# Altium schematic sheet Base IC.SchDoc
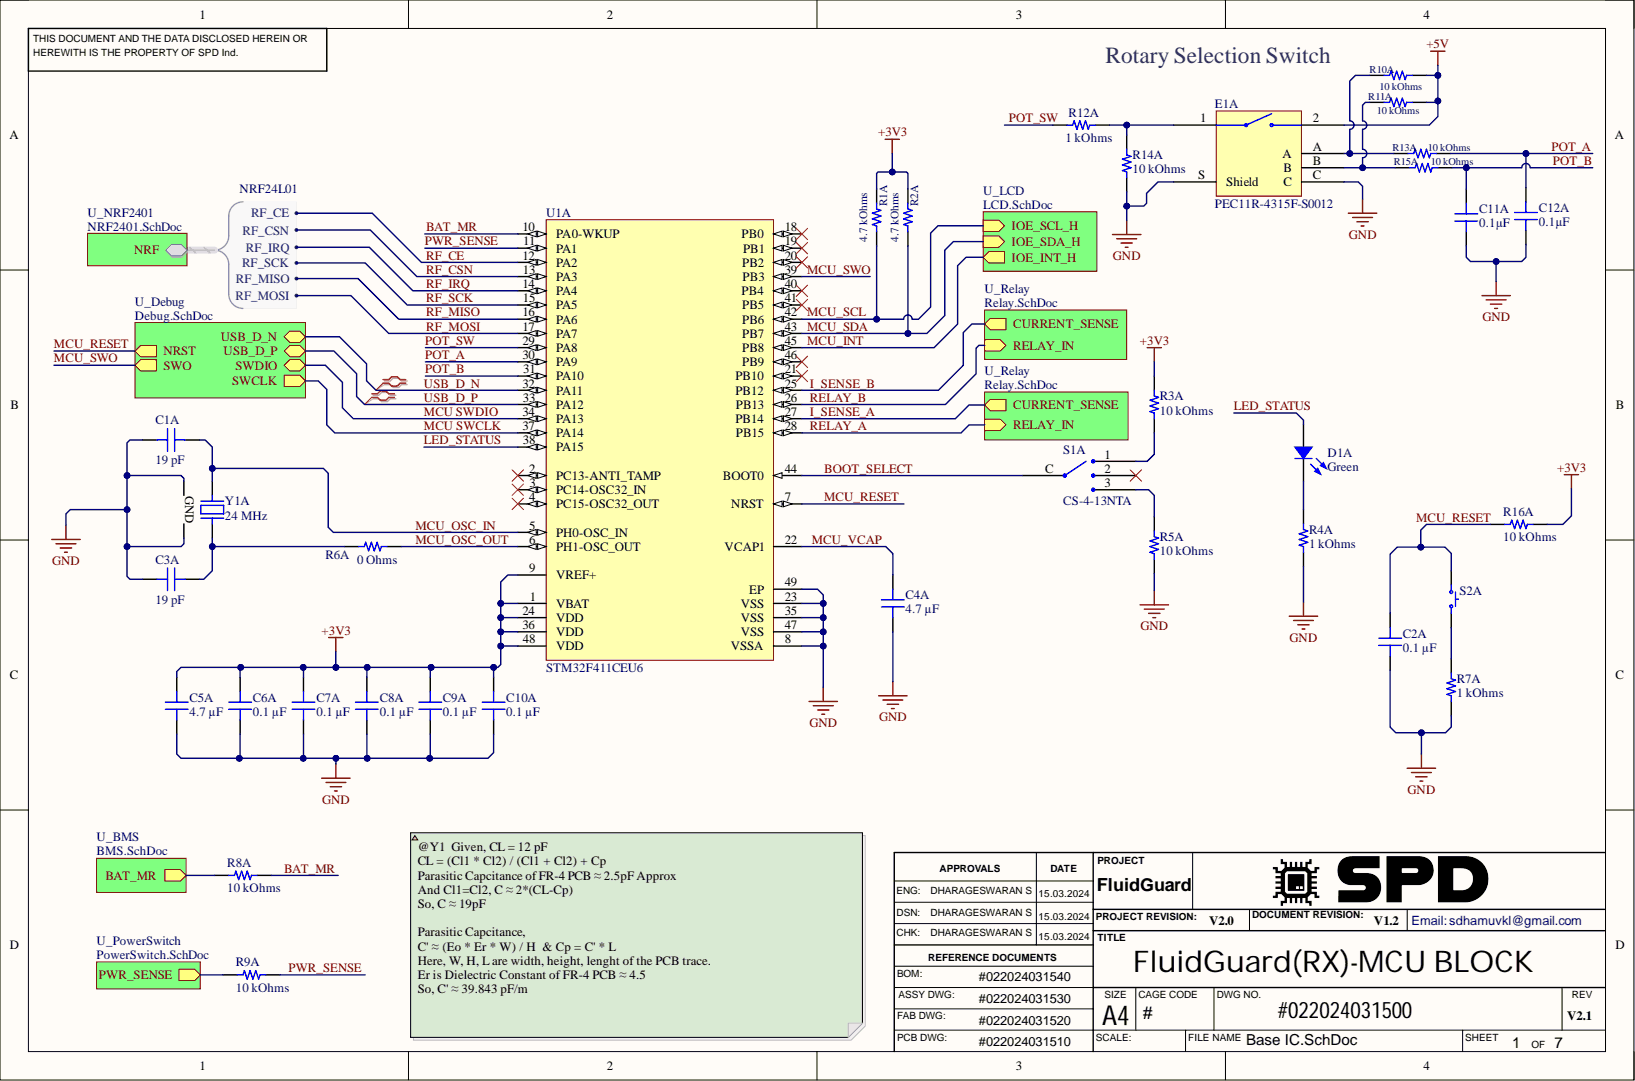
[diagram p0: Base IC.SchDoc]

## Parts
C1A  19 pF  [CAP 0402_1005]
C2A  0.1 µF  [CAP 0402_1005]
C3A  19 pF  [CAP 0402_1005]
C4A  4.7 µF  [CAP 0402_1005]
C5A  4.7 µF  [CAP 0402_1005]
C6A  0.1 µF  [CAP 0402_1005]
C7A  0.1 µF  [CAP 0402_1005]
C8A  0.1 µF  [CAP 0402_1005]
C9A  0.1 µF  [CAP 0402_1005]
C10A  0.1 µF  [CAP 0402_1005]
C11A  0.1µF  [CAP 0402_1005]
C12A  0.1µF  [CAP 0402_1005]
D1A  Green  [LED 0402_1005 GREEN]
E1A  PEC11R-4315F-S0012  [BOURNS PEC11R-4315F-S0012]
R1A  4.7 kOhms  [RES 0402_1005]
R2A  4.7 kOhms  [RES 0402_1005]
R3A  10 kOhms  [RES 0402_1005]
R4A  1 kOhms  [RES 0402_1005]
R5A  10 kOhms  [RES 0402_1005]
R6A  0 Ohms  [RES 0402_1005]
R7A  1 kOhms  [RES 0402_1005]
R8A  10 kOhms  [RES 0402_1005]
R9A  10 kOhms  [RES 0402_1005]
R10A  10 kOhms  [RES 0402_1005]
R11A  10 kOhms  [RES 0402_1005]
R12A  1 kOhms  [RES 0402_1005]
R13A  10 kOhms  [RES 0402_1005]
R14A  10 kOhms  [RES 0402_1005]
R15A  10 kOhms  [RES 0402_1005]
R16A  10 kOhms  [RES 0402_1005]
S1A  CS-4-13NTA  [NIDEC COPAL CS-4-13NTA]
S2A  EVP-AWED4A  [PANASONIC EVPAW]  (value not drawn)
U1A  STM32F411CEU6  [STM-UFQFPN48_L]
Y1A  24 MHz  [EPSON TSX-3225]

## Nets
+3V3: C5A.2 C6A.2 C7A.2 C8A.2 C9A.2 C10A.2 R1A.2 R2A.2 R3A.2 R16A.1 U1A.1(VBAT) U1A.9(VREF+) U1A.24(VDD) U1A.36(VDD) U1A.48(VDD)
+5V: E1A.2 R10A.2 R11A.2
GND: C1A.1 C2A.1 C3A.1 C4A.1 C5A.1 C6A.1 C7A.1 C8A.1 C9A.1 C10A.1 C11A.1 C12A.1 E1A.C E1A.S(Shield) R4A.1 R5A.1 R7A.1 R14A.1 U1A.8(VSSA) U1A.23(VSS) U1A.35(VSS) U1A.47(VSS) U1A.49(EP) Y1A.2 Y1A.4
BAT_MR: R8A.1 U1A.10(PA0-WKUP)
BOOT_SELECT: S1A.C U1A.44(BOOT0)
I_SENSE_A: U1A.27(PB14)
I_SENSE_B: U1A.25(PB12)
LED_STATUS: D1A.1 U1A.38(PA15)
MCU SWCLK: U1A.37(PA14)
MCU SWDIO: U1A.34(PA13)
MCU_INT: U1A.45(PB8)
MCU_OSC_IN: C1A.2 U1A.5(PH0-OSC_IN) Y1A.1
MCU_OSC_OUT: R6A.2 U1A.6(PH1-OSC_OUT)
MCU_RESET: C2A.2 R16A.2 S2A.1 U1A.7(NRST)
MCU_SCL: R1A.1 U1A.42(PB6)
MCU_SDA: R2A.1 U1A.43(PB7)
MCU_SWO: U1A.39(PB3)
MCU_VCAP: C4A.2 U1A.22(VCAP1)
POT_A: C12A.2 R13A.1 U1A.30(PA9)
POT_B: C11A.2 R15A.1 U1A.31(PA10)
POT_SW: R12A.2 U1A.29(PA8)
PWR_SENSE: R9A.1 U1A.11(PA1)
RELAY_A: U1A.28(PB15)
RELAY_B: U1A.26(PB13)
RF_CE: U1A.12(PA2)
RF_CSN: U1A.13(PA3)
RF_IRQ: U1A.14(PA4)
RF_MISO: U1A.16(PA6)
RF_MOSI: U1A.17(PA7)
RF_SCK: U1A.15(PA5)
USB_D_N: U1A.32(PA11)
USB_D_P: U1A.33(PA12)
NetC3A_2: C3A.2 R6A.1 Y1A.3
NetD1A_2: D1A.2 R4A.2
NetE1A_1: E1A.1 R12A.1 R14A.2
NetE1A_A: E1A.A R10A.1 R13A.2
NetE1A_B: E1A.B R11A.1 R15A.2
NetR3A_1: R3A.1 S1A.1
NetR5A_2: R5A.2 S1A.3
NetR7A_2: R7A.2 S2A.2
NetR8A_2: R8A.2
NetR9A_2: R9A.2
NetS1A_2: S1A.2
NetU1A_2: U1A.2(PC13-ANTI_TAMP)
NetU1A_3: U1A.3(PC14-OSC32_IN)
NetU1A_4: U1A.4(PC15-OSC32_OUT)
NetU1A_18: U1A.18(PB0)
NetU1A_19: U1A.19(PB1)
NetU1A_20: U1A.20(PB2)
NetU1A_21: U1A.21(PB10)
NetU1A_40: U1A.40(PB4)
NetU1A_41: U1A.41(PB5)
NetU1A_46: U1A.46(PB9)

## Library data of the parts
C1A C3A = GJM1555C1H190GB01D: CAP CER 19PF 50VDC 0402 C0G 2%; Manufacturer: Murata Electronics; Database Table Name: altium.Capacitors-Ceramic-0402; id: 5279; Temperature Coefficient: C0G, NP0; Voltage - Rated: 50V; Applications: RF, Microwave, High Frequency; Capacitance: 19 pF; Component Kind: Standard; ComponentLink1Description: Datasheet; ComponentLink1URL: https://search.murata.co.jp/Ceramy/image/img/A01X/G101/ENG/GJM1555C1H190GB01-01A.pdf; ComponentLink2Description: DigiKey Link; ComponentLink2URL: https://www.digikey.com/en/products/detail/murata-electronics/GJM1555C1H190GB01D/16033843; Device Package: 0402 (1005 Metric); Features: High Q, Low Loss; LastUpdated: 2023-01-03T20:03:22.137; Lifecycle Status: Active; Minimum Order: 1; Mounting Type: Surface Mount, MLCC; Operating Temperature: -55°C ~ 125°C; Package / Case: 0402 (1005 Metric); Packaging: TapeAndReel; Part Number: GJM1555C1H190GB01D; Part Status: Active; PartId: 41775; Price: 0.02; Series: GJM; Size / Dimension: 0.039" L x 0.020" W (1.00mm x 0.50mm); Supplier 1: DigiKey; Supplier Part Number 1: 490-GJM1555C1H190GB01DCT-ND; Thickness (Max): 0.022" (0.55mm); Tolerance: ±2%; Value: 19 pF; Library Name: Postgres Altium Library - altium_library.DbLib
C2A C6A C7A C8A C9A C10A = CL05B104KP5VPNC: CAP CER 100NF 10V X7R 0402; Manufacturer: Samsung Electro-Mechanics; Database Table Name: altium.Capacitors-Ceramic-0402; id: 7736; Tolerance: ±10%; Applications: Automotive; Capacitance: 0.1 µF; Component Kind: Standard; ComponentLink1Description: Datasheet; ComponentLink1URL: https://mm.digikey.com/Volume0/opasdata/d220001/medias/docus/5458/CL05B104KP5VPNC_Spec.pdf; ComponentLink2Description: DigiKey Link; ComponentLink2URL: https://www.digikey.com/en/products/detail/samsung-electro-mechanics/CL05B104KP5VPNC/20498482; Device Package: 0402 (1005 Metric); LastUpdated: 2023-11-05T20:02:55.743; Lifecycle Status: Active; Minimum Order: 1; Mounting Type: Surface Mount, MLCC; Operating Temperature: -55°C ~ 125°C; Package / Case: 0402 (1005 Metric); Packaging: TapeAndReel; Part Number: CL05B104KP5VPNC; PartId: 56690; Price: 0.01; Ratings: AEC-Q200; Series: CL; Size / Dimension: 0.039" L x 0.020" W (1.00mm x 0.50mm); Supplier 1: DigiKey; Supplier Part Number 1: 1276-CL05B104KP5VPNCCT-ND; Temperature Coefficient: X7R; Thickness (Max): 0.022" (0.55mm); Value: 0.1 µF; Voltage - Rated: 10V; Library Name: Postgres Altium Library - altium_library.DbLib
C4A C5A = GMC04X5R475K10NT: CAP0402 X5R 4.7UF 10% 10V; Manufacturer: Cal-Chip Electronics, Inc.; Database Table Name: altium.Capacitors-Ceramic-0402; id: 6422; Applications: General Purpose; Capacitance: 4.7 µF; Component Kind: Standard; ComponentLink1Description: Datasheet; ComponentLink1URL: https://88ce57.p3cdn1.secureserver.net/wp-content/uploads/2023/01/gmc_series-2.pdf; ComponentLink2Description: DigiKey Link; ComponentLink2URL: https://www.digikey.com/en/products/detail/cal-chip-electronics-inc/GMC04X5R475K10NT/18151135; Device Package: 0402 (1005 Metric); LastUpdated: 2023-04-21T19:03:30.743; Lifecycle Status: Active; Minimum Order: 1; Mounting Type: Surface Mount, MLCC; Operating Temperature: -55°C ~ 85°C; Package / Case: 0402 (1005 Metric); Packaging: TapeAndReel; Part Number: GMC04X5R475K10NT; Part Status: Active; PartId: 49814; Price: 0.01; Series: GMC; Size / Dimension: 0.039" L x 0.020" W (1.00mm x 0.50mm); Supplier 1: DigiKey; Supplier Part Number 1: 4713-GMC04X5R475K10NTCT-ND; Temperature Coefficient: X5R; Thickness (Max): 0.028" (0.70mm); Tolerance: ±10%; Value: 4.7 µF; Voltage - Rated: 10V; Library Name: Postgres Altium Library - altium_library.DbLib
C11A C12A = LD02ZD104KAB2A: CAP CER 0.1UF 10V X5R 0402; Manufacturer: AVX Corporation; Database Table Name: altium.Capacitors-Ceramic-0402; id: 74; Tolerance: ±10%; Applications: General Purpose; Capacitance: 0.1µF; Component Kind: Standard; ComponentLink1Description: Datasheet; ComponentLink1URL: http://datasheets.avx.com/LD-Series.pdf; ComponentLink2Description: DigiKey Link; ComponentLink2URL: http://digikey.com/product-detail/en/avx-corporation/LD02ZD104KAB2A/478-4926-1-ND/1858872; Device Package: 0402 (1005 Metric); LastUpdated: 2022-02-08T12:26:58.257; Lifecycle Status: Active; Minimum Order: 1; Mounting Type: Surface Mount, MLCC; Operating Temperature: -55°C ~ 85°C; Package / Case: 0402 (1005 Metric); Packaging: TapeAndReel; Part Number: LD02ZD104KAB2A; Part Status: Active; PartId: 1811; Price: 0.20; Series: LD; Size / Dimension: 0.039" L x 0.020" W (1.00mm x 0.50mm); Supplier 1: DigiKey; Supplier Part Number 1: 478-4926-1-ND; Temperature Coefficient: X5R; Thickness (Max): 0.022" (0.56mm); Value: 0.1µF; Voltage - Rated: 10V; Library Name: Postgres Altium Library - altium_library.DbLib
D1A = HSMG-C280: LED GREEN DIFFUSED 0402 SMD; Manufacturer: Broadcom Limited; Configuration: Standard; Current - Test: 20mA; Database Table Name: altium.LED-Indication; id: 697; Lens Color: White; Lens Size: 1.00mm x 0.50mm; Lens Style: Rectangle with Flat Top; Millicandela Rating: 15mcd; Viewing Angle: 130°; Voltage - Forward (Vf) (Typ): 2.2V; Wavelength - Dominant: 572nm; Wavelength - Peak: 570nm; Color: Green; Component Kind: Standard; ComponentLink1Description: Datasheet; ComponentLink1URL: https://docs.broadcom.com/docs/AV02-0602EN; ComponentLink2Description: DigiKey Link; ComponentLink2URL: https://www.digikey.com/en/products/detail/broadcom-limited/HSMG-C280/1235358; Device Package: 0402 (1005 Metric); Height (Max): 0.40mm; LastUpdated: 2023-01-02T20:56:26.750; Lens Transparency: Diffused; Lifecycle Status: Active; Minimum Order: 1; Mounting Type: Surface Mount; Package / Case: 0402 (1005 Metric); Packaging: TapeAndReel; Part Number: HSMG-C280; Part Status: Active; PartId: 697; Price: 0.08; Series: -; Size / Dimension: 1.00mm L x 0.50mm W; Supplier 1: DigiKey; Supplier Device Package: 0402; Supplier Part Number 1: 516-3066-1-ND; Value: Green; Library Name: Postgres Altium Library - altium_library.DbLib
E1A = PEC11R-4315F-S0012: ROTARY ENCODER MECHANICAL 12PPR; Manufacturer: Bourns Inc.; Manufacturer 1: Bourns; Actuator Type: 6mm Dia Flatted End; Built in Switch: Yes; Capacitance: 4315F; Database Table Name: altium.Encoders; id: 3; Number of Channels: 2; Output Type: Quadrature (Incremental); Pulses per Revolution: 12; Rotational Life (Cycles Min): 30K; Component Kind: Standard; ComponentLink1Description: Datasheet; ComponentLink1URL: https://www.bourns.com/docs/Product-Datasheets/PEC11R.pdf; ComponentLink2Description: DigiKey Link; ComponentLink2URL: http://digikey.com/product-detail/en/bourns-inc/PEC11R-4315F-S0012/PEC11R-4315F-S0012-ND/4699232; Detent: Yes; Encoder Type: Mechanical; Height: 13.4mm; LastUpdated: 2020-06-25T15:43:11.617; Length: 21.5mm; Lifecycle Status: Active; Manufacturer Part Number 1: PEC11R-4315F-S0012; Max Operating Temperature: 70°C; Min Operating Temperature: -30°C; Minimum Order: 1; Mount: Through Hole,Panel,PCB; Mounting Type: Panel, PCB Through Hole; Orientation: Vertical; Package Quantity: 75; Packaging: Unknown; Part Number: PEC11R-4315F-S0012; Part Status: Active; Price: 1.91; Pricing 1: 1=1.91000, 10=1.68200, 25=1.50800, 50=1.45000, 100=1.39200, 250=1.33400, 500=1.10200, 1000=1.04400, 5000=0.87000 (USD); RoHS: Yes; Series: PEC11R; Stock 1: 453; Supplier 1: DigiKey; Supplier Part Number 1: PEC11R-4315F-S0012-ND; Termination: PC Pin; Termination Style: PC Pin; Width: 12.5mm; Library Name: Postgres Altium Library - altium_library.DbLib
R1A R2A = AC0402FR-074K7L: RES SMD 4.7K OHM 1% 1/16W 0402; Manufacturer: Yageo; Database Table Name: altium.Resistors-SurfaceMount-0402; id: 4996; Power (Watts): 0.063W, 1/16W; Temperature Coefficient: ±100ppm/°C; Component Kind: Standard; ComponentLink1Description: Datasheet; ComponentLink1URL: https://www.yageo.com/upload/media/product/productsearch/datasheet/rchip/PYu-AC_51_RoHS_L_7.pdf; ComponentLink2Description: DigiKey Link; ComponentLink2URL: https://www.digikey.com/en/products/detail/yageo/AC0402FR-074K7L/5895396; Composition: Thick Film; Device Package: 0402 (1005 Metric); Features: Automotive AEC-Q200, Moisture Resistant; Height - Seated (Max): 0.015" (0.37mm); LastUpdated: 2023-01-02T20:21:09.847; Lifecycle Status: Active; Minimum Order: 1; Number Of Terminations: 2; Operating Temperature: -55°C ~ 155°C; Package / Case: 0402 (1005 Metric); Packaging: CutTape; Part Number: AC0402FR-074K7L; Part Status: Active; PartId: 12051; Price: 0.01; Ratings: AEC-Q200; Resistance: 4.7 kOhms; Series: AC; Size / Dimension: 0.039" L x 0.020" W (1.00mm x 0.50mm); Supplier 1: DigiKey; Supplier Device Package: 0402; Supplier Part Number 1: YAG3497CT-ND; Tolerance: ±1%; Value: 4.7 kOhms; Library Name: Postgres Altium Library - altium_library.DbLib
R3A R5A R8A R9A R10A R11A R13A R14A R15A R16A = AA0402FR-0710KL: RES SMD 10K OHM 1% 1/16W 0402; Manufacturer: Yageo; Database Table Name: altium.Resistors-SurfaceMount-0402; id: 10610; Power (Watts): 0.063W, 1/16W; Temperature Coefficient: ±150ppm/°C; Component Kind: Standard; ComponentLink1Description: Datasheet; ComponentLink1URL: https://www.yageo.com/upload/media/product/productsearch/datasheet/rchip/PYu-AA_51_RoHS_L_3.pdf; ComponentLink2Description: DigiKey Link; ComponentLink2URL: https://www.digikey.com/en/products/detail/yageo/AA0402FR-0710KL/5887729; Composition: Thick Film; Device Package: 0402 (1005 Metric); Features: Anti-Sulfur, Automotive AEC-Q200, Moisture Resistant; Height - Seated (Max): 0.015" (0.37mm); LastUpdated: 2023-01-02T20:20:10.303; Lifecycle Status: Active; Minimum Order: 1; Number Of Terminations: 2; Operating Temperature: -55°C ~ 155°C; Package / Case: 0402 (1005 Metric); Packaging: TapeAndReel; Part Number: AA0402FR-0710KL; Part Status: Active; PartId: 59190; Price: 0.01; Ratings: AEC-Q200; Resistance: 10 kOhms; Series: AA; Size / Dimension: 0.039" L x 0.020" W (1.00mm x 0.50mm); Supplier 1: DigiKey; Supplier Device Package: 0402; Supplier Part Number 1: 13-AA0402FR-0710KLCT-ND; Tolerance: ±1%; Value: 10 kOhms; Library Name: Postgres Altium Library - altium_library.DbLib
R4A R7A R12A = AA0402FR-071KL: RES SMD 1K OHM 1% 1/16W 0402; Manufacturer: Yageo; Database Table Name: altium.Resistors-SurfaceMount-0402; id: 10598; Power (Watts): 0.063W, 1/16W; Temperature Coefficient: ±150ppm/°C; Component Kind: Standard; ComponentLink1Description: Datasheet; ComponentLink1URL: https://www.yageo.com/upload/media/product/productsearch/datasheet/rchip/PYu-AA_51_RoHS_L_3.pdf; ComponentLink2Description: DigiKey Link; ComponentLink2URL: https://www.digikey.com/en/products/detail/yageo/AA0402FR-071KL/5887878; Composition: Thick Film; Device Package: 0402 (1005 Metric); Features: Anti-Sulfur, Automotive AEC-Q200, Moisture Resistant; Height - Seated (Max): 0.015" (0.37mm); LastUpdated: 2023-01-02T20:20:10.210; Lifecycle Status: Active; Minimum Order: 1; Number Of Terminations: 2; Operating Temperature: -55°C ~ 155°C; Package / Case: 0402 (1005 Metric); Packaging: TapeAndReel; Part Number: AA0402FR-071KL; Part Status: Active; PartId: 59178; Price: 0.01; Ratings: AEC-Q200; Resistance: 1 kOhms; Series: AA; Size / Dimension: 0.039" L x 0.020" W (1.00mm x 0.50mm); Supplier 1: DigiKey; Supplier Device Package: 0402; Supplier Part Number 1: 13-AA0402FR-071KLCT-ND; Tolerance: ±1%; Value: 1 kOhms; Library Name: Postgres Altium Library - altium_library.DbLib
R6A = AC0402JR-070RL: RES SMD 0 OHM JUMPER 1/16W 0402; Manufacturer: Yageo; Database Table Name: altium.Resistors-SurfaceMount-0402; id: 9339; Power (Watts): 0.063W, 1/16W; Component Kind: Standard; ComponentLink1Description: Datasheet; ComponentLink1URL: https://www.yageo.com/upload/media/product/productsearch/datasheet/rchip/PYu-AC_51_RoHS_L_7.pdf; ComponentLink2Description: DigiKey Link; ComponentLink2URL: https://www.digikey.com/en/products/detail/yageo/AC0402JR-070RL/2827807; Composition: Thick Film; Device Package: 0402 (1005 Metric); Features: Automotive AEC-Q200, Moisture Resistant; Height - Seated (Max): 0.015" (0.37mm); LastUpdated: 2023-01-02T20:20:32.243; Lifecycle Status: Active; Minimum Order: 1; Number Of Terminations: 2; Operating Temperature: -55°C ~ 155°C; Package / Case: 0402 (1005 Metric); Packaging: CutTape; Part Number: AC0402JR-070RL; Part Status: Active; PartId: 20617; Price: 0.01; Ratings: AEC-Q200; Resistance: 0 Ohms; Series: AC; Size / Dimension: 0.039" L x 0.020" W (1.00mm x 0.50mm); Supplier 1: DigiKey; Supplier Device Package: 0402; Supplier Part Number 1: 311-0.0LBCT-ND; Tolerance: Jumper; Value: 0 Ohms; Library Name: Postgres Altium Library - altium_library.DbLib
S1A = CS-4-13NTA: SWITCH ROTARY DIP SP3T 100MA 16V; Manufacturer: Nidec Copal Electronics; Actuator Level: Flush, Recessed; Actuator Type: Rotary for Tool; Database Table Name: altium.Switches-DIP; id: 6; Number Of Positions: 3; Pitch: 0.051" (1.30mm); Washable: Yes; Circuit: SP3T; Component Kind: Standard; ComponentLink1Description: Datasheet; ComponentLink1URL: https://www.nidec-copal-electronics.com/e/catalog/switch/cs-4.pdf; ComponentLink2Description: DigiKey Link; ComponentLink2URL: https://www.digikey.com/en/products/detail/nidec-copal-electronics/CS-4-13NTA/948346; Contact Finish: Gold; Contact Material: Copper Alloy; Current Rating (Amps): 100mA; Height Above Board: 0.091" (2.30mm); LastUpdated: 2022-09-26T03:19:43.077; Lifecycle Status: Active; Minimum Order: 1; Mounting Type: Surface Mount; Operating Temperature: -25°C ~ 70°C; Packaging: TapeAndReel; Part Number: CS-4-13NTA; Part Status: Active; PartId: 13; Price: 0.93; Series: CS-4; Supplier 1: DigiKey; Supplier Part Number 1: 563-1035-1-ND; Termination Style: J Lead; Library Name: Postgres Altium Library - altium_library.DbLib
S2A = EVP-AWED4A: 3.0MM X 2.0MM / 3.3N / 0.15MM ST; Manufacturer: Panasonic Electronic Components; Actuator Height Off Pcb, Vertical: 0.60mm; Actuator Orientation: Top Actuated; Actuator Type: Standard; Circuit: SPST-NO; Contact Rating @ Voltage: 0.02A @ 15VDC; Database Table Name: altium.Switches-Tactile; id: 5; Illumination: Non-Illuminated; Ingress Protection: IP67 - Dust Tight, Waterproof; Operating Force: 330gf; Outline: 3.00mm x 2.00mm; Switch Function: Off-Mom; Component Kind: Standard; ComponentLink1Description: Datasheet; ComponentLink1URL: https://industrial.panasonic.com/ac/cdn/e/control/switch/light-touch/catalog/sw_lt_eng_3020s.pdf; ComponentLink2Description: DigiKey Link; ComponentLink2URL: https://www.digikey.com/en/products/detail/panasonic-electronic-components/EVP-AWED4A/8568275; LastUpdated: 2023-01-02T21:07:29.980; Lifecycle Status: Active; Minimum Order: 1; Mounting Type: Surface Mount; Operating Temperature: -40°C ~ 85°C; Packaging: TapeAndReel; Part Number: EVP-AWED4A; Part Status: Active; Price: 0.16; Series: EVPAW; Supplier 1: DigiKey; Supplier Part Number 1: P123440CT-ND; Termination Style: SMD (SMT) Tab; Library Name: Postgres Altium Library - altium_library.DbLib
U1A = CMP-0243-00165-1: ARM Cortex-M4 32-bit MCU+FPU, 512 KB Flash, 128 KB RAM, 36 I/Os, 48-Pin UFQFPN, -40 to 85 degC, Tray; FLASH Size (Prog) (kB): 512; I/Os (High Current): 36; Internal RAM Size (kB): 128; Operating Frequency(F) (Processor speed) (MHz): 100; Supply Voltage(Vcc) min (V): 1.7; Component Kind: Standard; ComponentLink1Description: Manufacturer URL; ComponentLink1URL: http://www.st.com/; ComponentLink2Description: Datasheet; ComponentLink2URL: http://www.st.com/st-web-ui/static/active/en/resource/technical/document/datasheet/DM00115249.pdf; Core: ARM Cortex-M4; DatasheetVersion: Rev. 6; Library Name: Altium Content Vault; Mounting Technology: Surface Mount; Package Description: UFQFPN48; Package Reference: 48-Pin Ultra Thin Fine Pitch Quad Flat No-Lead, 7 x 7 x 0.6 mm Body, 0.5 mm Pitch, Exposed Pad; Packing: Tray
Y1A = TSX-3225 24.0000MF15X-AC3: CRYSTAL 24.0000MHZ 9PF SMD; Manufacturer: EPSON; Database Table Name: altium.Oscillators-Crystals; Esr (Equivalent Series Resistance): 40 Ohms; Frequency Stability: ±15ppm; Frequency Tolerance: ±10ppm; id: 441; Operating Mode: Fundamental; Component Kind: Standard; ComponentLink1Description: Datasheet; ComponentLink1URL: https://support.epson.biz/td/api/doc_check.php?dl=brief_TSX-3225&lang=en; ComponentLink2Description: DigiKey Link; ComponentLink2URL: https://www.digikey.com/en/products/detail/epson/TSX-3225-24-0000MF15X-AC3/1825444; Frequency: 24 MHz; Height - Seated (Max): 0.024" (0.60mm); LastUpdated: 2023-01-02T20:58:19.840; Lifecycle Status: Active; Load Capacitance: 9pF; Minimum Order: 1; Mounting Type: Surface Mount; Operating Temperature: -40°C ~ 85°C; Package / Case: 4-SMD, No Lead; Packaging: TapeAndReel; Part Number: TSX-3225 24.0000MF15X-AC3; Part Status: Active; PartId: 441; Price: 0.44; Series: TSX-3225; Size / Dimension: 0.126" L x 0.098" W (3.20mm x 2.50mm); Supplier 1: DigiKey; Supplier Part Number 1: SER3635CT-ND; Type: MHz Crystal; Value: 24 MHz; Library Name: Postgres Altium Library - altium_library.DbLib
